# Altium schematic sheet Gyro.SchDoc(Gyro)
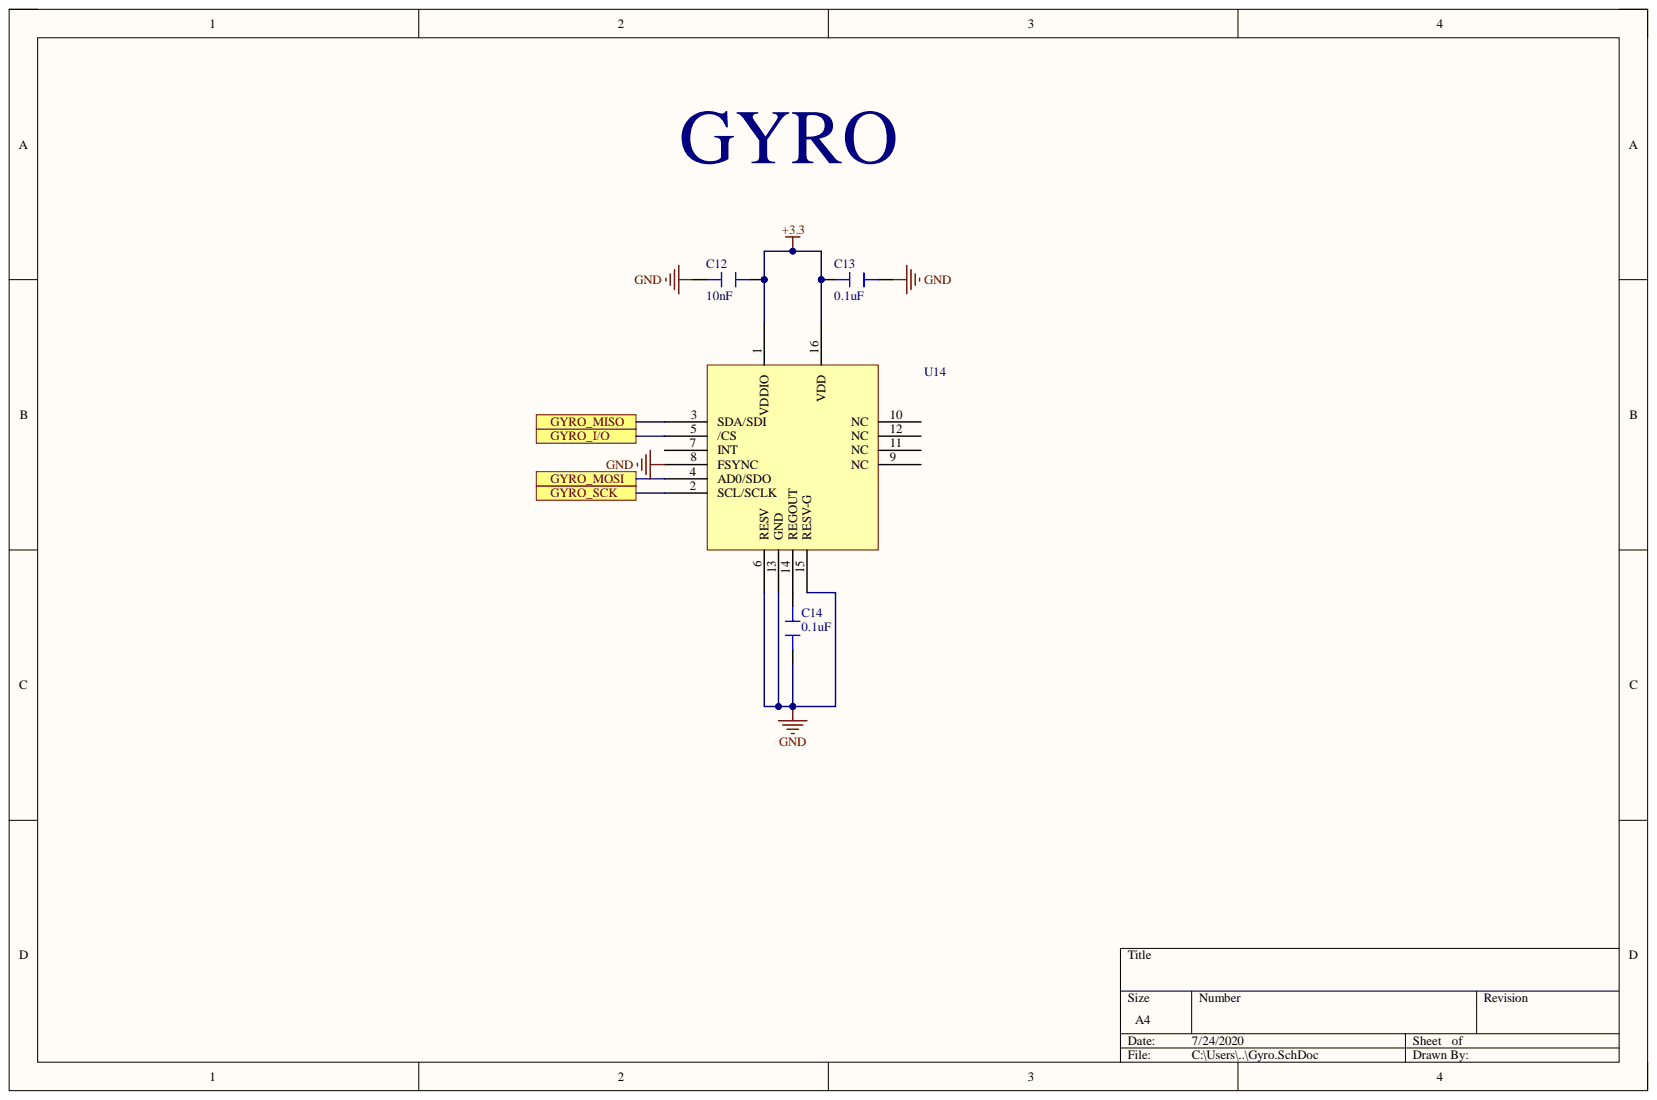
[diagram p0: Gyro.SchDoc]

## Parts
C12  10nF  [CAPACITOR 0805]
C13  0.1uF  [CAPACITOR 0805]
C14  0.1uF  [CAPACITOR 0805]
U14  Gyroscope  [GYROSCOPE]  (value not drawn)

## Ports
GYRO_I/O, GYRO_MISO, GYRO_MOSI, GYRO_SCK

## Nets
+3.3: C12.2 C13.1 U14.1(VDDIO) U14.16(VDD)
GND: C12.1 C13.2 C14.1 U14.6(RESV) U14.8(FSYNC) U14.13(GND) U14.15(RESV-G)
GYRO_I/O: U14.5(/CS) ; port GYRO_I/O
GYRO_MISO: U14.3(SDA/SDI) ; port GYRO_MISO
GYRO_MOSI: U14.4(AD0/SDO) ; port GYRO_MOSI
GYRO_SCK: U14.2(SCL/SCLK) ; port GYRO_SCK
NetC14_2: C14.2 U14.14(REGOUT)

Not connected: U14 7(INT) 9(NC) 10(NC) 11(NC) 12(NC)

## Library data of the parts
C12 = Capacitor  10nF 0805: Multilayer Ceramic Capacitors MLCC - SMD/SMT .01uF 50volts 10%; Manufacturer: Vishay Vitramon; Manufacturer Part Number: VJ0805Y103KXAAC; Dielectric: X7R; Voltage Rating: 50V; Capacitance: 10nF; Case Code (Imperial): 0805; Case Code (Metric): 2012; Case/Package: 0805; Category: Multilayer Ceramic Capacitors MLCC - SMD/SMT; Component Kind: Standard; ComponentLink1Description: http://www.vishay.com/docs/45051/vjvitramonpackaging.pdf?src-supplier=Digi-Key; ComponentLink1URL: http://www.vishay.com/docs/45051/vjvitramonpackaging.pdf?src-supplier=Digi-Key; ComponentLink2Description: http://www.farnell.com/datasheets/2049140.pdf?src-supplier=Element14; ComponentLink2URL: http://www.farnell.com/datasheets/2049140.pdf?src-supplier=Element14; Contact Plating: Tin,Matte; Depth: 1.25mm; Height: 1.45mm; Lead Free: Lead Free; Length: 2mm; Max Operating Temperature: 125°C; Min Operating Temperature: -55°C; Mount: Surface Mount; Package Quantity: 1; Packaging: Tape and Reel; Pricing 1: 1=0.26, 10=0.202, 50=0.202, 100=0.09, 500=0.074, 1000=0.051, 3000=0.042, 6000=0.038, 9000=0.033, 10000=0.033, 24000=0.03 (USD); Radiation Hardening: No; REACH SVHC: Unknown; Stock 1: 543640; Supplier 1: Mouser; Termination: SMD/SMT; Thickness: 0.057inch; Tolerance: 10%; Voltage Rating (DC): 50V; Width: 1.25mm; Library Name: BiricuticoBonito.SchLib
C13 C14 = Capacitor  0.1uF 0805: Multilayer Ceramic Capacitors MLCC - SMD/SMT .1uF 50volts 10%; Manufacturer: Vishay Vitramon; Manufacturer Part Number: VJ0805Y104KXAAT; Capacitance: 100nF; Dielectric: X7R; Voltage: 50V; Case Code (Imperial): 0805; Case Code (Metric): 2012; Case/Package: 0805; Category: Multilayer Ceramic Capacitors MLCC - SMD/SMT; Component Kind: Standard; ComponentLink1Description: http://www.mouser.com/ds/2/427/vjcommercialseries-222796.pdf?src-supplier=Mouser; ComponentLink1URL: http://www.mouser.com/ds/2/427/vjcommercialseries-222796.pdf?src-supplier=Mouser; ComponentLink2Description: http://www.vishay.com/docs/45199/vjcommercialseries.pdf?src-supplier=Digi-Key; ComponentLink2URL: http://www.vishay.com/docs/45199/vjcommercialseries.pdf?src-supplier=Digi-Key; ComponentLink3Description: http://www.vishay.com/docs/45199/vjcommercialseries.pdf?src-supplier=Future+Electronics; ComponentLink3URL: http://www.vishay.com/docs/45199/vjcommercialseries.pdf?src-supplier=Future+Electronics; Depth: 1.25mm; Height: 1.45mm; Lead Free: Lead Free; Length: 2mm; Max Operating Temperature: 125°C; Min Operating Temperature: -55°C; Mount: Surface Mount; Package Quantity: 1; Packaging: Tape and Reel; Pricing 1: 1=0.2, 10=0.2, 50=0.162, 100=0.108, 500=0.095, 1000=0.084, 3000=0.072, 6000=0.069, 9000=0.065, 10000=0.065 (USD); Radiation Hardening: No; REACH SVHC: Unknown; Stock 1: 207342; Supplier 1: Mouser; Supplier Part Number 1: 77-VJ0805Y104KXAAT; Termination: SMD/SMT; Thickness: 0.057inch; Tolerance: 10%; Voltage Rating: 50V; Voltage Rating (DC): 50V; Weight: 0.000194oz; Width: 0.049inch; Library Name: BiricuticoBonito.SchLib
U14 = Gyroscope: MEMS GYROSCOPE 1-AXIS 16QFN; Manufacturer: TDK InvenSense; Axis: Z; Bandwidth: 5Hz; Operating Supply Current: 2.8mA; Output Type: SPI,I2C; Category: Gyroscopes; Component Kind: Standard; ComponentLink1Description: https://store.invensense.com/datasheets/invensense/PS-ISZ-2510A-00.pdf?src-supplier=Digi-Key; ComponentLink1URL: https://store.invensense.com/datasheets/invensense/PS-ISZ-2510A-00.pdf?src-supplier=Digi-Key; Manufacturer Part Number: ISZ-2510; Max Operating Temperature: 85°C; Min Operating Temperature: -40°C; Packaging: Tape and Reel; Stock 1: 0; Supplier 1: Mouser; Supplier Part Number 1: 410-ISZ-2510; Library Name: BiricuticoBonito.SchLib
